# Altium schematic sheet 6_GPS.SchDoc(U_6_GPS)
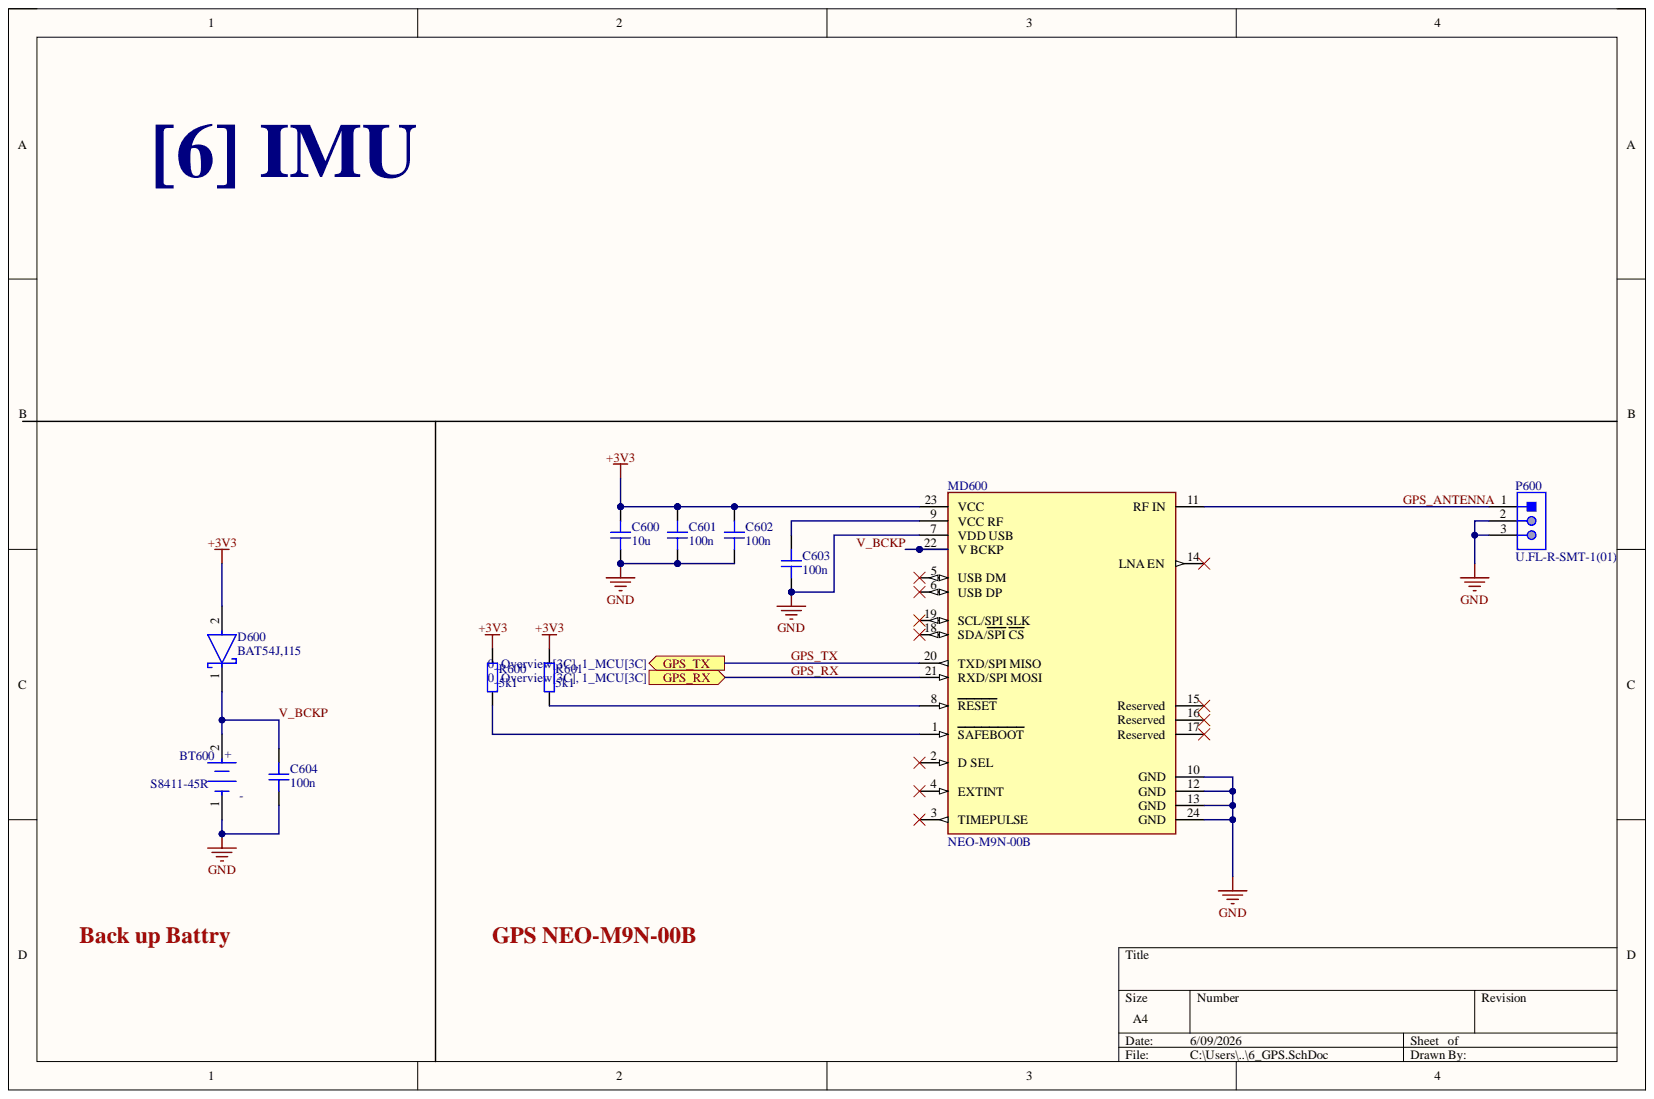
[diagram p0: 6_GPS.SchDoc]

## Parts
BT600  S8411-45R  [FP-S8411-45R-MFG]
C600  10u  [CAP_0805]
C601  100n  [CAP_0603]
C602  100n  [CAP_0603]
C603  100n  [CAP_0603]
C604  100n  [CAP_0603]
D600  BAT54J,115  [FP-SOD323F-MFG]
MD600  NEO-M9N-00B  [FP-NEO-M9N-00B-MFG]
P600  U.FL-R-SMT-1(01)  [HRS-U.FL-R-SMT-3_V]
R600  5k1  [RES_0603]
R601  5k1  [RES_0603]

## Ports
GPS_RX, GPS_TX

## Nets
+3V3: C600.2 C601.1 C602.1 D600.2 MD600.23(VCC) R600.2 R601.2
GND: BT600.1 C600.1 C601.2 C602.2 C603.2 C604.2 MD600.7(VDD_USB) MD600.10(GND) MD600.12(GND) MD600.13(GND) MD600.24(GND) P600.2 P600.3
GPS_ANTENNA: MD600.11(RF_IN) P600.1
GPS_RX: MD600.21(RXD/SPI_MOSI) ; port GPS_RX
GPS_TX: MD600.20(TXD/SPI_MISO) ; port GPS_TX
V_BCKP: BT600.2 C604.1 D600.1 MD600.22(V_BCKP)
NetC603_1: C603.1 MD600.9(VCC_RF)
NetMD600_1: MD600.1(SAFEBOOT) R600.1
NetMD600_2: MD600.2(D_SEL)
NetMD600_3: MD600.3(TIMEPULSE)
NetMD600_4: MD600.4(EXTINT)
NetMD600_5: MD600.5(USB_DM)
NetMD600_6: MD600.6(USB_DP)
NetMD600_8: MD600.8(RESET) R601.1
NetMD600_14: MD600.14(LNA_EN)
NetMD600_15: MD600.15(Reserved)
NetMD600_16: MD600.16(Reserved)
NetMD600_17: MD600.17(Reserved)
NetMD600_18: MD600.18(SDA/SPI_CS)
NetMD600_19: MD600.19(SCL/SPI_SLK)

## Library data of the parts
BT600 = CMP-15817-000007-1: BATTERY HOLDER COIN 12MM SMD; Number of Batteries: 1; Case/Package: SMD/SMT; Component Kind: Standard; Contact Plating: Bronze; Library Name: Altium Content Vault; Material: Polyphenylene; Max Operating Temperature: 105°C; Min Operating Temperature: -55°C; Mount: Surface Mount; Number of Cells: 1; Package Quantity: 500; Packaging: Tape and Reel; REACH SVHC: No SVHC; Termination: SMD/SMT
C600 = CAP_0805: Capacitor SMD 0805; Component Kind: Standard; Library Name: Schematic-Lib-PhilsLab.SchLib
C601-C604 = CAP_0603: Capacitor SMD 0603; Component Kind: Standard; Library Name: Schematic-Lib-PhilsLab.SchLib
D600 = CMP-14398-000037-1: DIODE SCHOTTKY 30V 200MA SOD323F; Component Kind: Standard; Library Name: Altium Content Vault; RoHS Compliant: Yes
MD600 = CMP-207130-000006-2: M9 standard precision module; Manufacturer: u-blox; Interface: I2C,SPI,UART,USB; Max Supply Voltage: 3.6V; Min Supply Voltage: 1.65V; Sensitivity: -167dBm; Automotive: No; Category: UNK; Component Kind: Standard; ComponentLink2Description: Datasheet; ComponentLink2URL: https://www.u-blox.com/sites/default/files/NEO-M9N_DataSheet_%28UBX-19014285%29.pdf; Device Class L1: Integrated Circuits (ICs); Device Class L2: RF Semiconductors and Devices; Device Class L3: RF Receivers; Footprint Url: https://www.u-blox.com/sites/default/files/NEO-M9N_Integrationmanual_%28UBX-19014286%29.pdf; Height: 2.6mm; Lead Free: Yes; Library Name: Altium Content Vault; Manufacturer Part Number: NEO-M9N-00B; Octopart: https://octopart.com/neo-m9n-00b-u-blox-107315722; Package: 0; RoHS: TRUE; Temperature: -40°C to +85°C; Temperature Range High: +85°C; Temperature Range Low: -40°C
P600 = CMP-2000-05153-1: Ultra Small Coaxial Connector, 6 GHz, -40 to 90 degC, 3-Pin SMD, RoHS, Bulk; Manufacturer: Hirose Electric; Body Finish: Silver; Body Material: PhosphorBronze; Center Contact Plating: Gold; Connector Style: U.FL; Connector Type: Jack,MalePin; Contact Termination: Solder; Dielectric Material: LiquidCrystalPolymer(LCP); Dynamic Catalog: U.FLSeries; Featured Product: HiroseU.FLSeries; Frequency - Max: 6GHz; Impedance: 50Ohm; Minimum Quantity: UltraMiniatureCoaxial; Other Names: H122041  U.FL-R-SMT-1(01)-ND; Category: Connectors,Interconnects; Center Contact Material: PhosphorBronze; Component Kind: Standard; Datasheet URL: https://datasheet.ciiva.com/26945/getdatasheetpartid-475239-26945414.pdf; Datasheet Version: Feb-09; Family: CoaxialConnectors(RF); Fastening Type: Snap-On; Housing Color: Silver; Library Name: Altium Content Vault; Manufacturer URL: https://www.hirose.com; Mounting Type: SurfaceMount; Online Catalog: U.FLSeries; Operating Temperature: -40degC~90degC; Package Description: 3-Pin Surface Mount Device, Body 2.6 x 2.6 mm; Package Reference: U.FL-R-SMT; Packaging: Bulk; Quantity Available: Bulk; Series: U.FL; Shield Termination: Solder; Standard Package: 100; Unit PriceUSD: U.FL
R600 R601 = RES_0603: Resistor SMD 0603; Component Kind: Standard; Library Name: Schematic-Lib-PhilsLab.SchLib
